# Altium schematic sheet IMU.SchDoc(IMU)
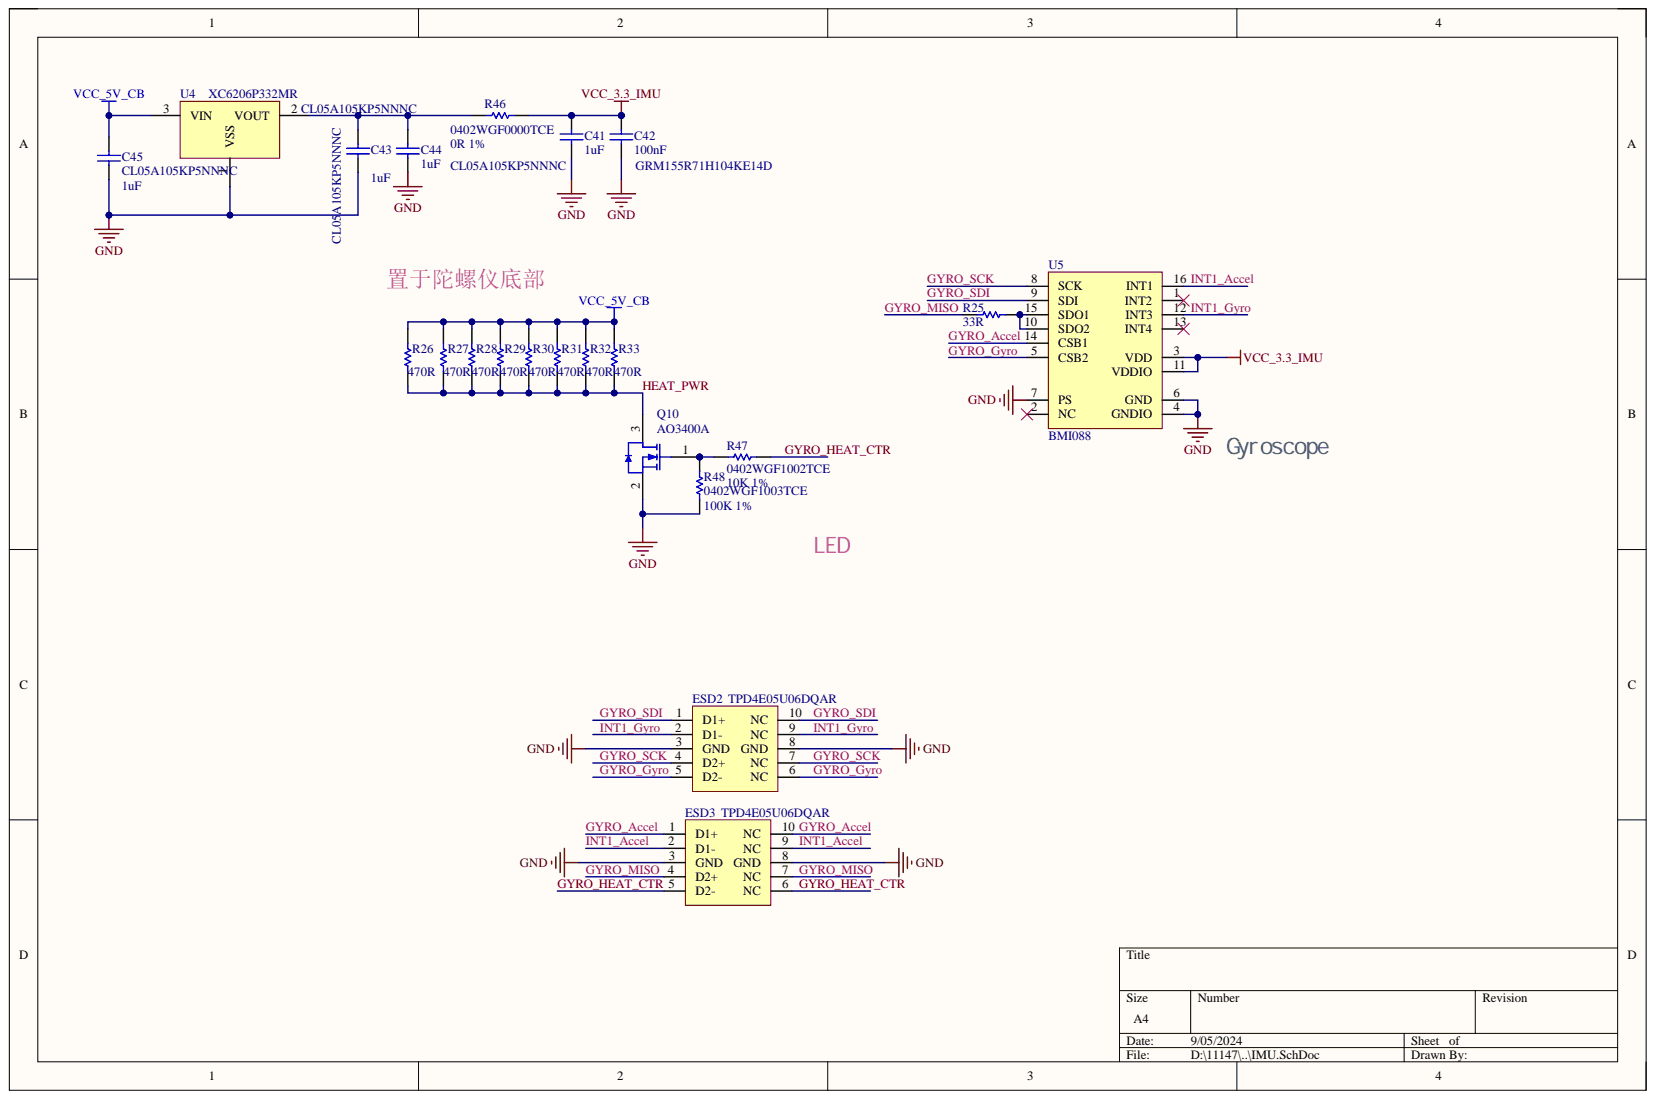
[diagram p0: IMU.SchDoc]

## Parts
C41  CL05A105KP5NNNC  value 1uF  [0402_C_3D]
C42  GRM155R71H104KE14D  value 100nF  [0402_C_3D]
C43  CL05A105KP5NNNC  value 1uF  [0402_C_3D]
C44  CL05A105KP5NNNC  value 1uF  [0402_C_3D]
C45  CL05A105KP5NNNC  value 1uF  [0402_C_3D]
ESD2  TPD4E05U06DQAR  [USON-10_L2.5-W1.0-P0.50-BL]
ESD3  TPD4E05U06DQAR  [USON-10_L2.5-W1.0-P0.50-BL]
Q10  AO3400A  [SOT-23-3D]
R25  value 33R  [0402_R_3D]  (hidden comment: R0603)
R26  value 470R  [0402_R_3D]  (hidden comment: 0402 5%)
R27  value 470R  [0402_R_3D]  (hidden comment: R0603)
R28  value 470R  [0402_R_3D]  (hidden comment: R0603)
R29  value 470R  [0402_R_3D]  (hidden comment: R0603)
R30  value 470R  [0402_R_3D]  (hidden comment: R0603)
R31  value 470R  [0402_R_3D]  (hidden comment: R0603)
R32  value 470R  [0402_R_3D]  (hidden comment: R0603)
R33  value 470R  [0402_R_3D]  (hidden comment: R0603)
R46  0402WGF0000TCE  value 0R 1%  [0402_R_3D]
R47  0402WGF1002TCE  value 10K 1%  [0402_R_3D]
R48  0402WGF1003TCE  value 100K 1%  [0402_R_3D]
U4  XC6206P332MR  [SOT-23-3D]
U5  BMI088  [LGA-16_L4.5-W3.0-P0.50_ST]

## Nets
GND: C41.1 C42.2 C43.2 C44.1 C45.2 ESD2.3(GND) ESD2.8(GND) ESD3.3(GND) ESD3.8(GND) Q10.2 R48.2 U4.1 U5.4(GNDIO) U5.6(GND) U5.7(PS)
VCC_3.3_IMU: C41.2 C42.1 R46.1 U5.3(VDD) U5.11(VDDIO)
VCC_5V_CB: C45.1 R26.1 R27.1 R28.2 R29.2 R30.2 R31.2 R32.2 R33.2 U4.3
GYRO_Accel: ESD3.1(D1+) ESD3.10(NC) U5.14(CSB1)
GYRO_Gyro: ESD2.5(D2-) ESD2.6(NC) U5.5(CSB2)
GYRO_HEAT_CTR: ESD3.5(D2-) ESD3.6(NC) R47.2
GYRO_MISO: ESD3.4(D2+) ESD3.7(NC) R25.1
GYRO_SCK: ESD2.4(D2+) ESD2.7(NC) U5.8(SCK)
GYRO_SDI: ESD2.1(D1+) ESD2.10(NC) U5.9(SDI)
HEAT_PWR: Q10.3 R26.2 R27.2 R28.1 R29.1 R30.1 R31.1 R32.1 R33.1
INT1_Accel: ESD3.2(D1-) ESD3.9(NC) U5.16(INT1)
INT1_Gyro: ESD2.2(D1-) ESD2.9(NC) U5.12(INT3)
NetC43_1: C43.1 C44.2 R46.2 U4.2
NetQ10_1: Q10.1 R47.1 R48.1
NetR25_2: R25.2 U5.10(SDO2) U5.15(SDO1)

Not connected: U5 1(INT2) 2(NC) 13(INT4)

## Library data of the parts
C41-C45 = Cap: Capacitor; Component Kind: Standard; Library Name: Fszhang.IntLib
ESD2 ESD3 = TPD4E05U06DQAR: ESD for SSUSB; Component Kind: Standard; Library Name: My package.SchLib
Q10 = AO3400A N道沟 X0YV1T SOT-23: MOS(场效应管); Component Kind: Standard; Library Name: JLC.IntLib; Package: SOT-23
R25-R33 R48 = Res1: Resistor; Component Kind: Standard; Library Name: Miscellaneous Devices.IntLib
  R25-R33: LatestRevisionDate: 17-Jul-2002; LatestRevisionNote: Re-released for DXP Platform.; PackageDescription: Axial Device, Thru-Hole; 2 Leads; 0.3 in Pin Spacing; PackageReference: AXIAL-0.3; Published: 8-Jun-2000; Signal Integrity: Res; Simulation: RESISTOR; Publisher: Altium Limited
R46 = Res1: Resistor; Value: 0R 1%; Component Kind: Standard; Library Name: Miscellaneous Devices.IntLib
R47 = Res1: Resistor; Value: 10K 1%; Component Kind: Standard; Library Name: Miscellaneous Devices.IntLib
U4 = XC6206P332MR: LDO 662K; Component Kind: Standard; Library Name: My package.SchLib
U5 = BMI088: Component Kind: Standard; Library Name: My package.SchLib
